# Altium schematic sheet mainboard.SchDoc
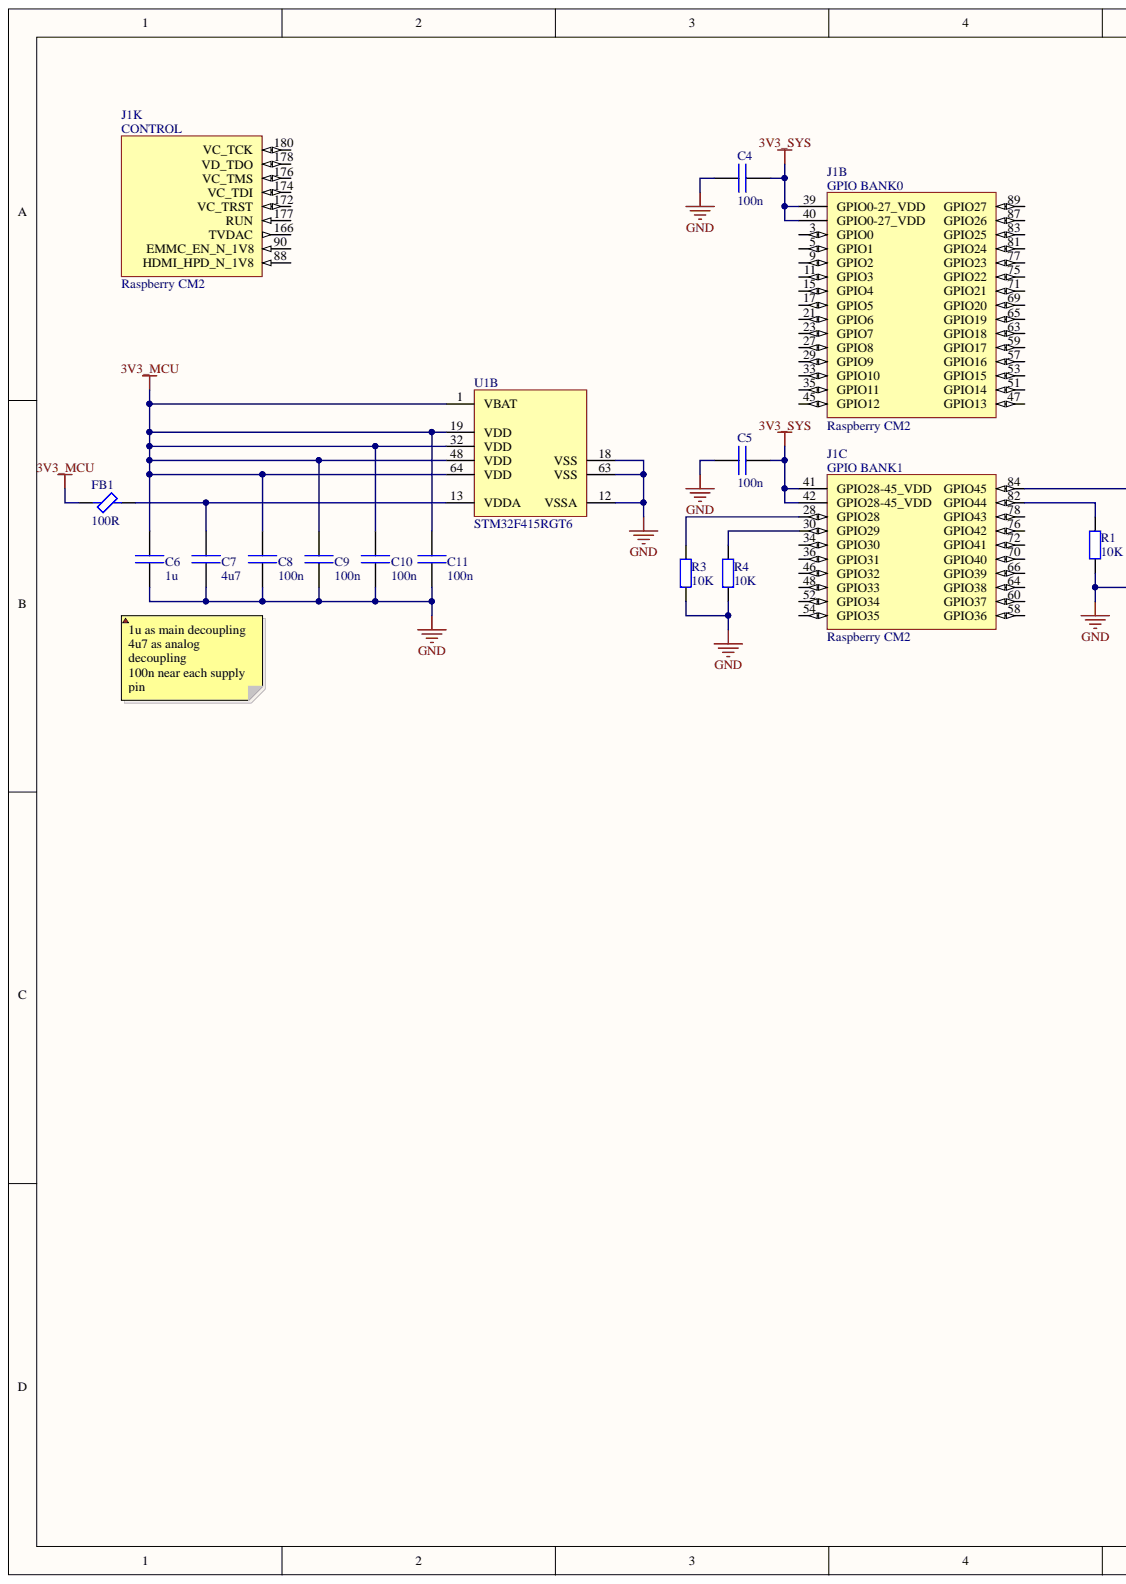
[diagram p0: mainboard.SchDoc, left]
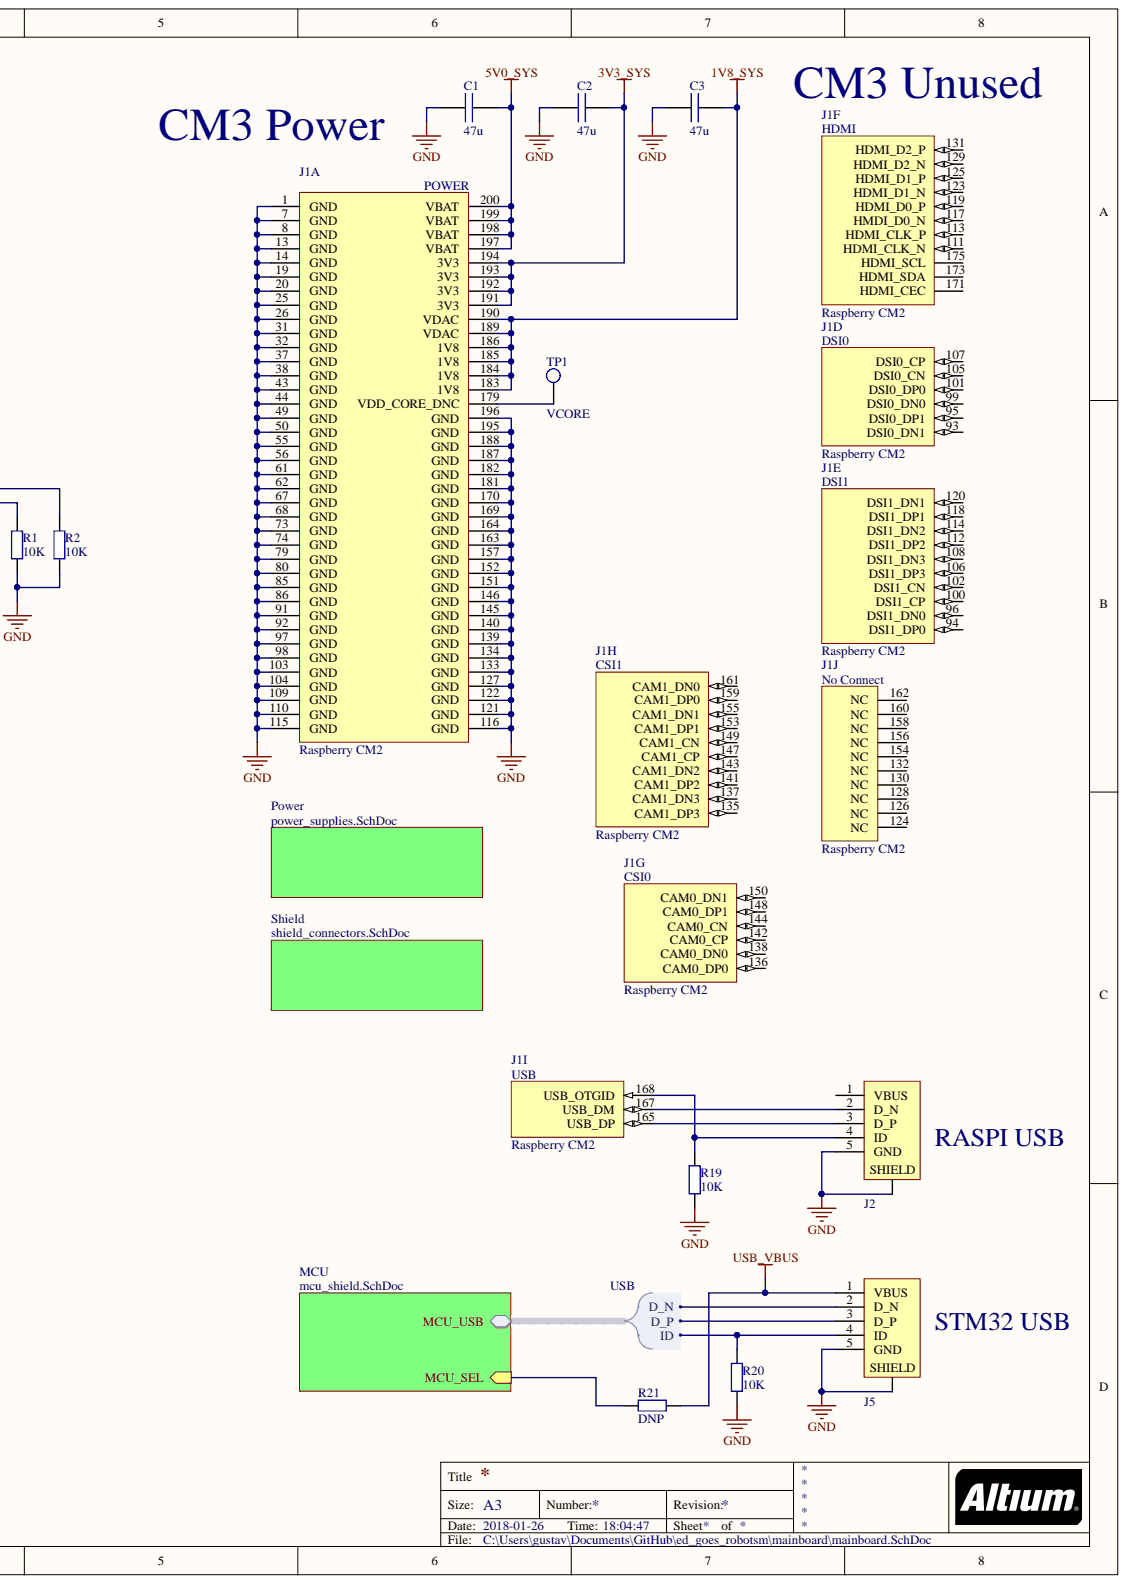
[diagram p1: mainboard.SchDoc, right]

## Parts
C1  value 47u  [CAPC1005X06N]  (hidden comment: cap)
C2  value 47u  [CAPC1005X06N]  (hidden comment: cap)
C3  value 47u  [CAPC1005X06N]  (hidden comment: cap)
C4  value 100n  [CAPC1210X10N]  (hidden comment: cap)
C5  value 100n  [CAPC1210X10N]  (hidden comment: cap)
C6  value 1u  [CAPC1210X10N]  (hidden comment: cap)
C7  value 4u7  [CAPC1210X10N]  (hidden comment: cap)
C8  value 100n  [CAPC1005X06N]  (hidden comment: cap)
C9  value 100n  [CAPC1005X06N]  (hidden comment: cap)
C10  value 100n  [CAPC1005X06N]  (hidden comment: cap)
C11  value 100n  [CAPC1005X06N]  (hidden comment: cap)
FB1  value 100R  [INDC4625X38N]  (hidden comment: ferrite)
J1  Raspberry CM2  [raspi_cm3]  (parts A,B,C,D,E,F,G,H,I,J,K)
J2  usb_micro  [usb_micro_10118192-0001LF]  (value not drawn)
J5  usb_micro  [usb_micro_10118192-0001LF]  (value not drawn)
R1  value 10K  [RESC2012X06N]  (hidden comment: res)
R2  value 10K  [RESC2012X06N]  (hidden comment: res)
R3  value 10K  [RESC1005X04N]  (hidden comment: res)
R4  value 10K  [RESC1005X04N]  (hidden comment: res)
R19  value 10K  [RESC1005X04N]  (hidden comment: res)
R20  value 10K  [RESC1005X04N]  (hidden comment: res)
R21  value DNP  [RESC1005X04N]  (hidden comment: res)
TP1  VCORE  [testpoint_smd]
U1  STM32F415RGT6  [STM-LQFP64_N]  (part B)

## Nets
1V8_SYS: C3.1 J1.183(1V8) J1.184(1V8) J1.185(1V8) J1.186(1V8) J1.189(VDAC) J1.190(VDAC)
3V3_MCU: C6.2 C8.2 C9.2 C10.2 C11.2 FB1.1 U1.1(VBAT) U1.19(VDD) U1.32(VDD) U1.48(VDD) U1.64(VDD)
3V3_SYS: C2.1 C4.2 C5.2 J1.39(GPIO0-27_VDD) J1.40(GPIO0-27_VDD) J1.41(GPIO28-45_VDD) J1.42(GPIO28-45_VDD) J1.191(3V3) J1.192(3V3) J1.193(3V3) J1.194(3V3)
5V0_SYS: C1.1 J1.197(VBAT) J1.198(VBAT) J1.199(VBAT) J1.200(VBAT)
GND: C1.2 C2.2 C3.2 C4.1 C5.1 C6.1 C7.1 C8.1 C9.1 C10.1 C11.1 J1.1(GND) J1.7(GND) J1.8(GND) J1.13(GND) J1.14(GND) J1.19(GND) J1.20(GND) J1.25(GND) J1.26(GND) J1.31(GND) J1.32(GND) J1.37(GND) J1.38(GND) J1.43(GND) J1.44(GND) J1.49(GND) J1.50(GND) J1.55(GND) J1.56(GND) J1.61(GND) J1.62(GND) J1.67(GND) J1.68(GND) J1.73(GND) J1.74(GND) J1.79(GND) J1.80(GND) J1.85(GND) J1.86(GND) J1.91(GND) J1.92(GND) J1.97(GND) J1.98(GND) J1.103(GND) J1.104(GND) J1.109(GND) J1.110(GND) J1.115(GND) J1.116(GND) J1.121(GND) J1.122(GND) J1.127(GND) J1.133(GND) J1.134(GND) J1.139(GND) J1.140(GND) J1.145(GND) J1.146(GND) J1.151(GND) J1.152(GND) J1.157(GND) J1.163(GND) J1.164(GND) J1.169(GND) J1.170(GND) J1.181(GND) J1.182(GND) J1.187(GND) J1.188(GND) J1.195(GND) J1.196(GND) J2.5(GND) J2.SHIELD J5.5(GND) J5.SHIELD R1.1 R2.1 R3.1 R4.1 R19.1 R20.1 U1.12(VSSA) U1.18(VSS) U1.63(VSS)
USB_VBUS: J5.1(VBUS) R21.2
NetC7_2: C7.2 FB1.2 U1.13(VDDA)
NetJ1_3: J1.3(GPIO0)
NetJ1_5: J1.5(GPIO1)
NetJ1_9: J1.9(GPIO2)
NetJ1_11: J1.11(GPIO3)
NetJ1_15: J1.15(GPIO4)
NetJ1_17: J1.17(GPIO5)
NetJ1_21: J1.21(GPIO6)
NetJ1_23: J1.23(GPIO7)
NetJ1_27: J1.27(GPIO8)
NetJ1_28: J1.28(GPIO28) R3.2
NetJ1_29: J1.29(GPIO9)
NetJ1_30: J1.30(GPIO29) R4.2
NetJ1_33: J1.33(GPIO10)
NetJ1_34: J1.34(GPIO30)
NetJ1_35: J1.35(GPIO11)
NetJ1_36: J1.36(GPIO31)
NetJ1_45: J1.45(GPIO12)
NetJ1_46: J1.46(GPIO32)
NetJ1_47: J1.47(GPIO13)
NetJ1_48: J1.48(GPIO33)
NetJ1_51: J1.51(GPIO14)
NetJ1_52: J1.52(GPIO34)
NetJ1_53: J1.53(GPIO15)
NetJ1_54: J1.54(GPIO35)
NetJ1_57: J1.57(GPIO16)
NetJ1_58: J1.58(GPIO36)
NetJ1_59: J1.59(GPIO17)
NetJ1_60: J1.60(GPIO37)
NetJ1_63: J1.63(GPIO18)
NetJ1_64: J1.64(GPIO38)
NetJ1_65: J1.65(GPIO19)
NetJ1_66: J1.66(GPIO39)
NetJ1_69: J1.69(GPIO20)
NetJ1_70: J1.70(GPIO40)
NetJ1_71: J1.71(GPIO21)
NetJ1_72: J1.72(GPIO41)
NetJ1_75: J1.75(GPIO22)
NetJ1_76: J1.76(GPIO42)
NetJ1_77: J1.77(GPIO23)
NetJ1_78: J1.78(GPIO43)
NetJ1_81: J1.81(GPIO24)
NetJ1_82: J1.82(GPIO44) R1.2
NetJ1_83: J1.83(GPIO25)
NetJ1_84: J1.84(GPIO45) R2.2
NetJ1_87: J1.87(GPIO26)
NetJ1_88: J1.88(HDMI_HPD_N_1V8)
NetJ1_89: J1.89(GPIO27)
NetJ1_90: J1.90(EMMC_EN_N_1V8)
NetJ1_93: J1.93(DSI0_DN1)
NetJ1_94: J1.94(DSI1_DP0)
NetJ1_95: J1.95(DSI0_DP1)
NetJ1_96: J1.96(DSI1_DN0)
NetJ1_99: J1.99(DSI0_DN0)
NetJ1_100: J1.100(DSI1_CP)
NetJ1_101: J1.101(DSI0_DP0)
NetJ1_102: J1.102(DSI1_CN)
NetJ1_105: J1.105(DSI0_CN)
NetJ1_106: J1.106(DSI1_DP3)
NetJ1_107: J1.107(DSI0_CP)
NetJ1_108: J1.108(DSI1_DN3)
NetJ1_111: J1.111(HDMI_CLK_N)
NetJ1_112: J1.112(DSI1_DP2)
NetJ1_113: J1.113(HDMI_CLK_P)
NetJ1_114: J1.114(DSI1_DN2)
NetJ1_117: J1.117(HMDI_D0_N)
NetJ1_118: J1.118(DSI1_DP1)
NetJ1_119: J1.119(HDMI_D0_P)
NetJ1_120: J1.120(DSI1_DN1)
NetJ1_123: J1.123(HDMI_D1_N)
NetJ1_124: J1.124(NC)
NetJ1_125: J1.125(HDMI_D1_P)
NetJ1_126: J1.126(NC)
NetJ1_128: J1.128(NC)
NetJ1_129: J1.129(HDMI_D2_N)
NetJ1_130: J1.130(NC)
NetJ1_131: J1.131(HDMI_D2_P)
NetJ1_132: J1.132(NC)
NetJ1_135: J1.135(CAM1_DP3)
NetJ1_136: J1.136(CAM0_DP0)
NetJ1_137: J1.137(CAM1_DN3)
NetJ1_138: J1.138(CAM0_DN0)
NetJ1_141: J1.141(CAM1_DP2)
NetJ1_142: J1.142(CAM0_CP)
NetJ1_143: J1.143(CAM1_DN2)
NetJ1_144: J1.144(CAM0_CN)
NetJ1_147: J1.147(CAM1_CP)
NetJ1_148: J1.148(CAM0_DP1)
NetJ1_149: J1.149(CAM1_CN)
NetJ1_150: J1.150(CAM0_DN1)
NetJ1_153: J1.153(CAM1_DP1)
NetJ1_154: J1.154(NC)
NetJ1_155: J1.155(CAM1_DN1)
NetJ1_156: J1.156(NC)
NetJ1_158: J1.158(NC)
NetJ1_159: J1.159(CAM1_DP0)
NetJ1_160: J1.160(NC)
NetJ1_161: J1.161(CAM1_DN0)
NetJ1_162: J1.162(NC)
NetJ1_165: J1.165(USB_DP) J2.3(D_P)
NetJ1_166: J1.166(TVDAC)
NetJ1_167: J1.167(USB_DM) J2.2(D_N)
NetJ1_168: J1.168(USB_OTGID) J2.4(ID) R19.2
NetJ1_171: J1.171(HDMI_CEC)
NetJ1_172: J1.172(VC_TRST)
NetJ1_173: J1.173(HDMI_SDA)
NetJ1_174: J1.174(VC_TDI)
NetJ1_175: J1.175(HDMI_SCL)
NetJ1_176: J1.176(VC_TMS)
NetJ1_177: J1.177(RUN)
NetJ1_178: J1.178(VD_TDO)
NetJ1_179: J1.179(VDD_CORE_DNC) TP1.1
NetJ1_180: J1.180(VC_TCK)
NetJ2_1: J2.1(VBUS)
NetJ5_2: J5.2(D_N)
NetJ5_3: J5.3(D_P)
NetJ5_4: J5.4(ID) R20.2
NetR21_1: R21.1

## Library data of the parts
C1-C11 = cap: Capacitor; Component Kind: Standard; Library Name: lib_passives.IntLib
FB1 = ferrite: Inductor; Component Kind: Standard; Library Name: lib_passives.IntLib
J1 = raspicm2: Component Kind: Standard; Library Name: lib_raspberry.SchLib
J2 J5 = usb_micro: Micro USB Receptacle; Component Kind: Standard; Library Name: lib_connectors.IntLib
R1-R4 R19-R21 = res: Resistor; Component Kind: Standard; Library Name: lib_passives.IntLib
TP1 = testpoint: Test point; Component Kind: Standard; Library Name: lib_passives.IntLib
U1 = STM32F415RGT6: ARM Cortex-M4 32-bit MCU+FPU, 210 DMIPS, 1024 kB Flash, 192 kB Internal RAM, 51 I/Os, 64-pin LQFP, -40 to 85 degC, Tray; Manufacturer: STMicroelectronics; D/A Converter: 2x12-bit; FLASH Size (Prog) (kB): 1024; I/Os (High Current): 51; Internal RAM Size (kB): 192; Operating Frequency(F) (Processor speed) (MHz): 168; Supply Voltage(Vcc) max (V): 3.6; Supply Voltage(Vcc) min (V): 1.8; Component Kind: Standard; ComponentLink1Description: Manufacturer URL; ComponentLink1URL: http://www.st.com/web/en/home.html; ComponentLink2Description: Datasheet; ComponentLink2URL: http://www.st.com/st-web-ui/static/active/en/resource/technical/document/datasheet/DM00035129.pdf; ComponentLink3Description: Package Specification; ComponentLink3URL: http://www.st.com/st-web-ui/static/active/en/resource/technical/document/datasheet/DM00037051.pdf; Core: ARM Cortex-M4; DatasheetVersion: Rev. 4; Library Name: lib_st.IntLib; Mounting Technology: Surface Mount; PackageDescription: 64-Pin Low Profile Quad Flat Package, 10 x 10 mm Body, 0.5 mm Pitch; PackageReference: LQFP64; PackageVersion: Rev. 4, 06/2013; Packing: Tray; PartNumber: STM32F415RGT6; Supplier 1: Digi-Key; Supplier 2: Farnell; Supplier 3: Newark; Supplier 4: Mouser; Supplier Part Number 1: 497-11907-ND; Supplier Part Number 2: 2064370; Supplier Part Number 3: 73T2335; Supplier Part Number 4: 511-STM32F415RGT6
